# Altium schematic sheet BotStop_LCD_WIFI_CON.SchDoc
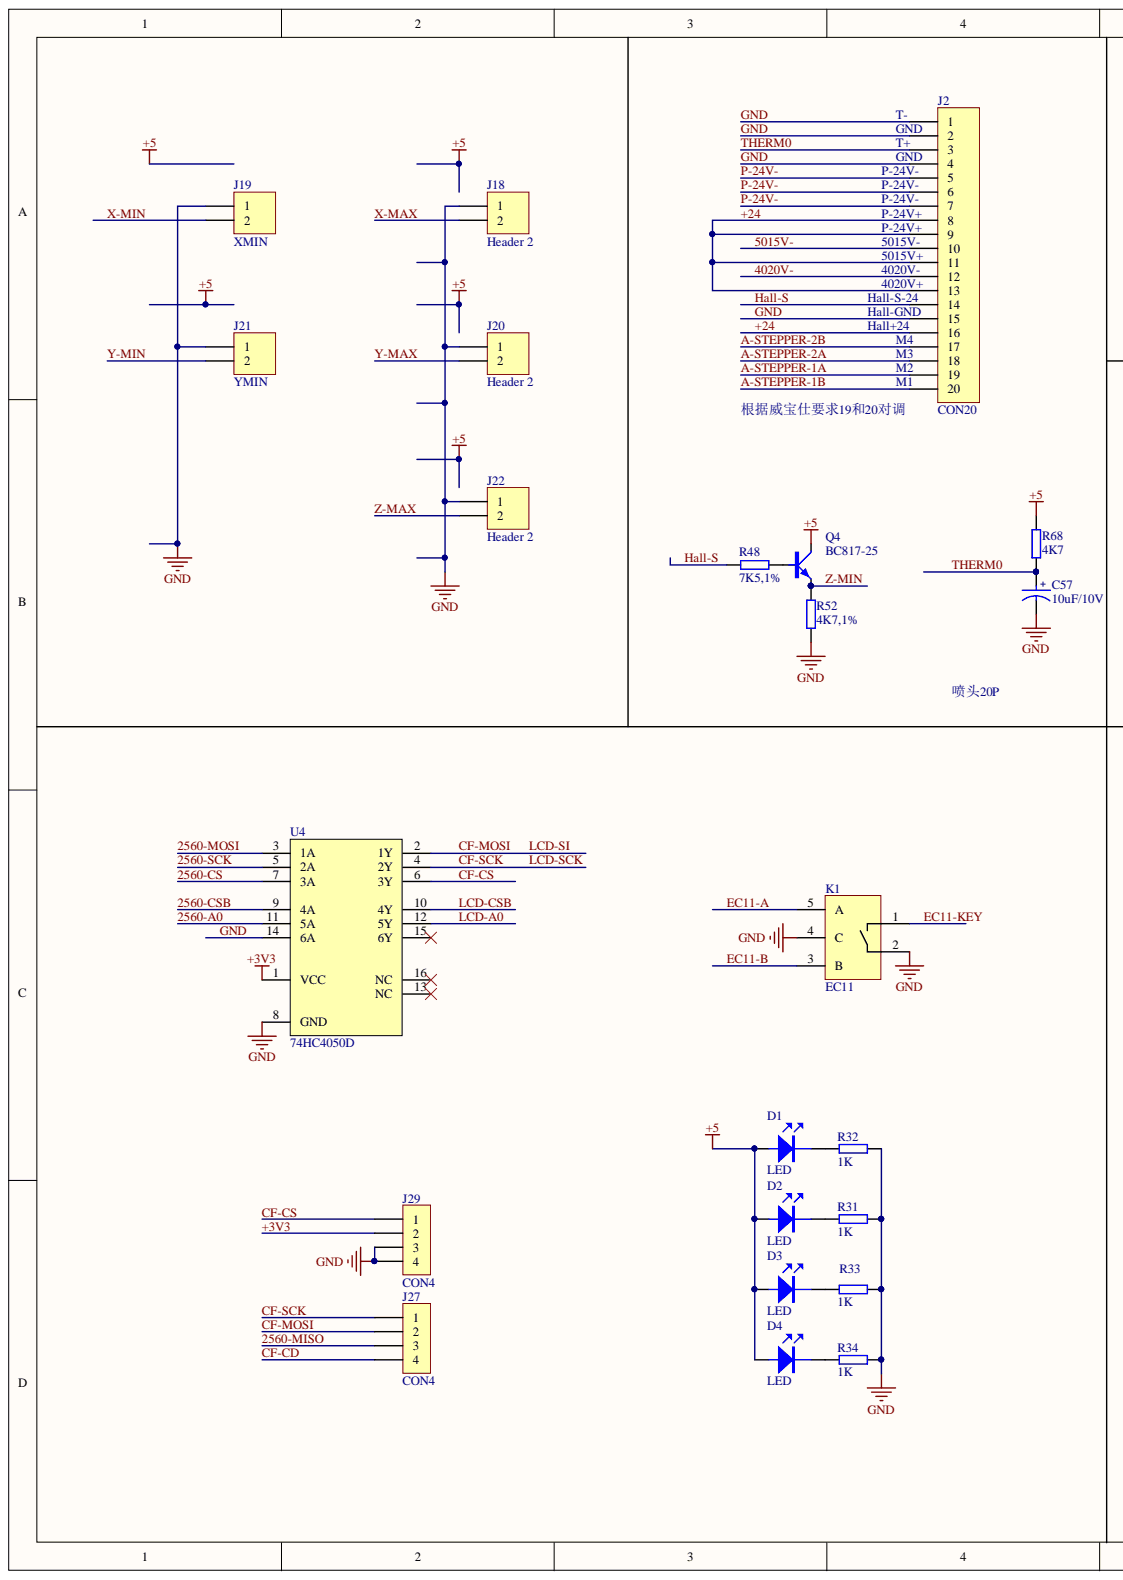
[diagram p0: BotStop_LCD_WIFI_CON.SchDoc, left]
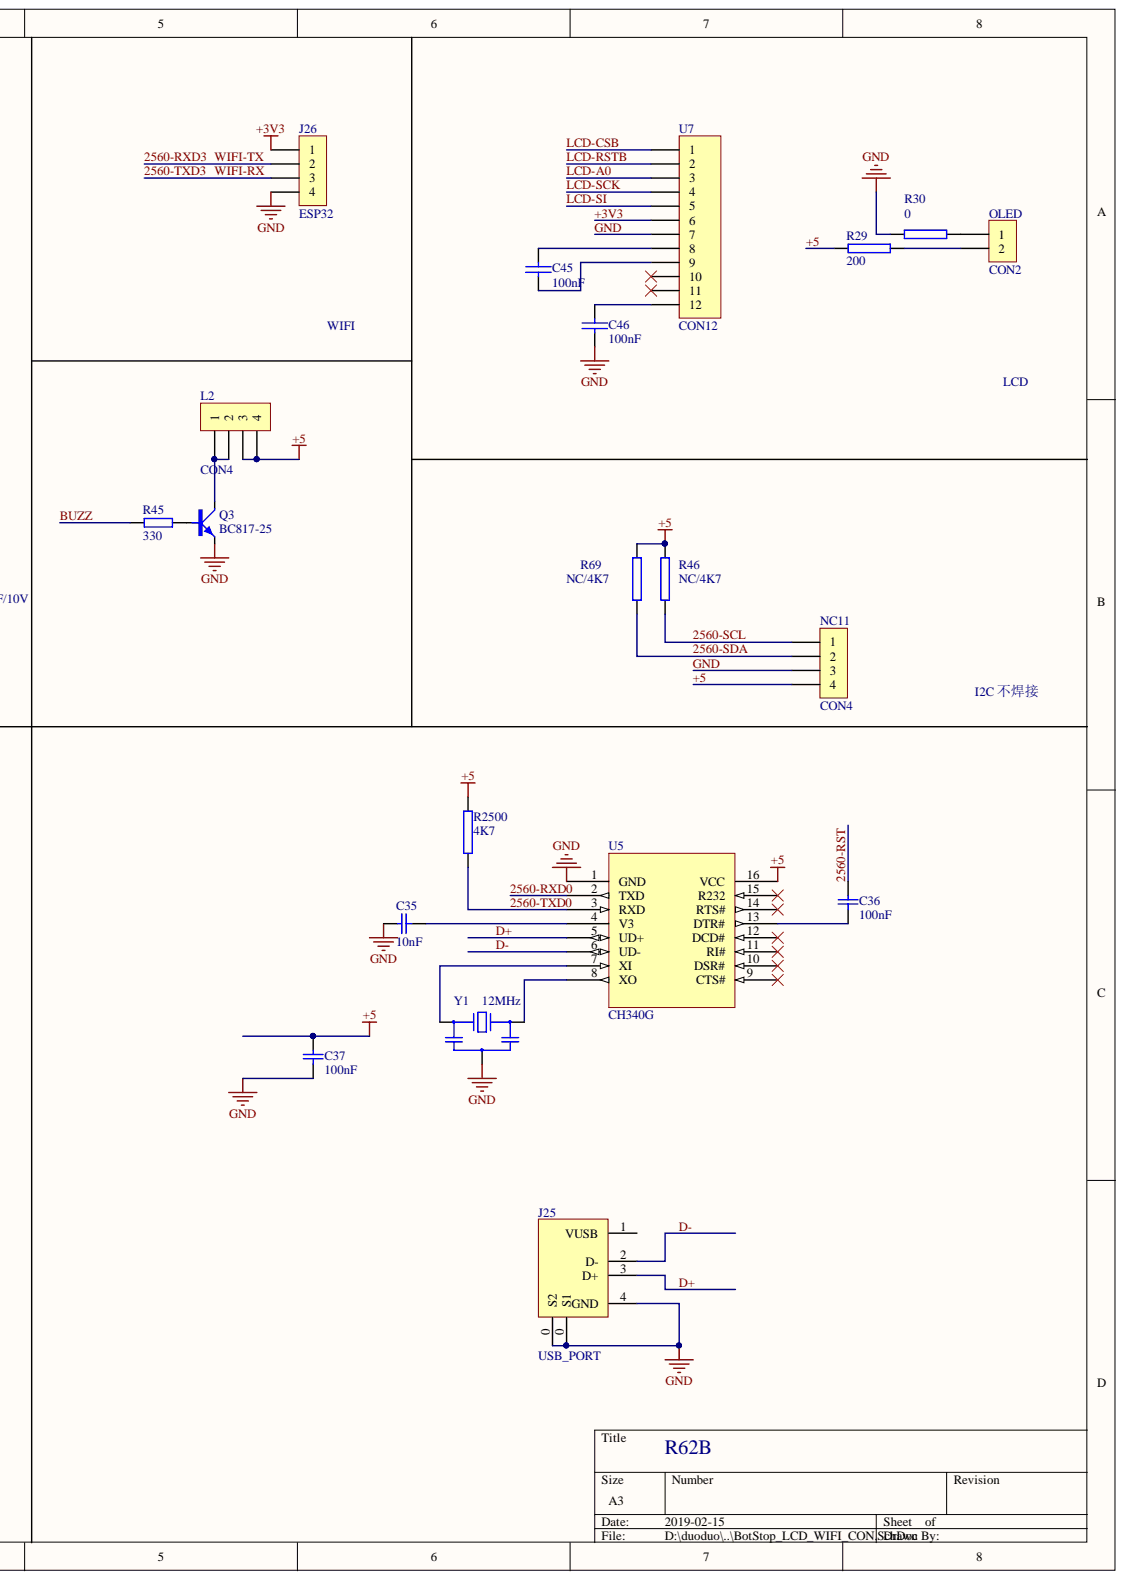
[diagram p1: BotStop_LCD_WIFI_CON.SchDoc, right]

## Parts
C35  10nF  [0603]
C36  100nF  [0603]
C37  100nF  [0603]
C45  100nF  [0603]
C46  100nF  [0603]
C57  10uF/10V  [1206]
D1  LED  [0805D]
D2  LED  [0805D]
D3  LED  [0805D]
D4  LED  [0805D]
J2  CON20  [IDC-20-100H-N]
J18  Header 2  [XH2.54-2]
J19  XMIN  [XH2.54-2]
J20  Header 2  [XH2.54-2]
J21  YMIN  [XH2.54-2]
J22  Header 2  [XH2.54-2]
J25  USB_PORT  [USB-B]
J26  ESP32  [XH2.54-4-A]
J27  CON4  [23]
J29  CON4  [23]
K1  EC11  [EC11-SMT]
L2  CON4  [BUZZ-SMT]
NC11  CON4  [SIP-4]
OLED  CON2  [OLED]
Q3  BC817-25  [SOT-23-3L]
Q4  BC817-25  [SOT-23-3L]
R29  200  [0603]
R30  0  [0603]
R31  1K  [0603]
R32  1K  [0603]
R33  1K  [0603]
R34  1K  [0603]
R45  330  [0603]
R46  NC/4K7  [0603]
R48  7K5,1%  [0603]
R52  4K7,1%  [0603]
R68  4K7  [0603]
R69  NC/4K7  [0603]
R2500  4K7  [0603]
U4  74HC4050D  [LC-SOIC-16_150mil]
U5  CH340G  [LC-SOIC-16_150mil]
U7  CON12  [FPC12-0.5]
Y1  12MHz  [CSTCE-G]

## Nets
2560-A0: U4.11(5A)
2560-CS: U4.7(3A)
2560-CSB: U4.9(4A)
2560-MISO: J27.3
2560-MOSI: U4.3(1A)
2560-RST: C36.1
2560-RXD0: U5.2(TXD)
2560-RXD3: J26.2
2560-SCK: U4.5(2A)
2560-SCL: NC11.1 R46.1
2560-SDA: NC11.2 R69.1
2560-TXD0: R2500.2 U5.3(RXD)
2560-TXD3: J26.3
4020V-: J2.12
5015V-: J2.10
+3V3: J26.1 J29.2 U4.1(VCC) U7.6
+5: C37.1 D1.1 D2.1 D3.1 D4.1 L2.3 L2.4 NC11.4 Q4.3 R29.2 R46.2 R68.2 R69.2 R2500.1 U5.16(VCC)
+24: J2.8 J2.9 J2.11 J2.13 J2.16
A-STEPPER-1A: J2.19
A-STEPPER-1B: J2.20
A-STEPPER-2A: J2.18
A-STEPPER-2B: J2.17
BUZZ: R45.1
CF-CD: J27.4
CF-CS: J29.1 U4.6(3Y)
CF-MOSI: J27.2 U4.2(1Y) U7.5
CF-SCK: J27.1 U4.4(2Y) U7.4
D+: J25.3(D+) U5.5(UD+)
D-: J25.2(D-) U5.6(UD-)
EC11-A: K1.5(A)
EC11-B: K1.3(B)
EC11-KEY: K1.1
GND: C35.1 C37.2 C46.1 C57.2 J2.1 J2.2 J2.4 J2.15 J18.1 J19.1 J20.1 J21.1 J22.1 J25.0(S2) J25.4(GND) J26.4 J29.3 J29.4 K1.2 K1.4(C) NC11.3 Q3.2 R30.1 R31.2 R32.2 R33.2 R34.2 R52.1 U4.8(GND) U4.14(6A) U5.1(GND) U7.7 Y1.2
Hall-S: J2.14 R48.2
LCD-A0: U4.12(5Y) U7.3
LCD-CSB: U4.10(4Y) U7.1
LCD-RSTB: U7.2
P-24V-: J2.5 J2.6 J2.7
THERM0: C57.1 J2.3 R68.1
X-MAX: J18.2
X-MIN: J19.2
Y-MAX: J20.2
Y-MIN: J21.2
Z-MAX: J22.2
Z-MIN: Q4.2 R52.2
NetC35_2: C35.2 U5.4(V3)
NetC36_2: C36.2 U5.13(DTR#)
NetC45_1: C45.1 U7.9
NetC45_2: C45.2 U7.8
NetC46_2: C46.2 U7.12
NetD1_2: D1.2 R32.1
NetD2_2: D2.2 R31.1
NetD3_2: D3.2 R33.1
NetD4_2: D4.2 R34.1
NetL2_1: L2.1 L2.2 Q3.3
NetOLED_1: OLED.1 R30.2
NetOLED_2: OLED.2 R29.1
NetQ3_1: Q3.1 R45.2
NetQ4_1: Q4.1 R48.1
NetU5_7: U5.7(XI) Y1.1
NetU5_8: U5.8(XO) Y1.3

Not connected: J25 1(VUSB); U4 13(NC) 15(6Y) 16(NC); U5 9(CTS#) 10(DSR#) 11(RI#) 12(DCD#) 14(RTS#) 15(R232); U7 10 11

## Library data of the parts
C35-C37 C45 C46 = CAP: Capacitor; Component Kind: Standard
  C35-C37: Library Name: DMSch.SCHLIB
  C45 C46: Library Name: Miscellaneous Devices.SCHLIB
C57 = CAPACITOR POL: Component Kind: Standard; Description: Capacitor Pol; Library Name: DMSch.SCHLIB
J2 = CON20: Connector; Component Kind: Standard; Library Name: Miscellaneous Devices.SCHLIB
J18-J22 = Header 2: Header, 2-Pin; Component Kind: Standard; LatestRevisionDate: 17-Jul-2002; LatestRevisionNote: Re-released for DXP Platform.; Library Name: Miscellaneous Connectors.IntLib; Signal Integrity: Connector; Publisher: Altium Limited
J25 = USB_PORT: USB-PORT; Component Kind: Standard; Library Name: DMSch.SCHLIB
J26 J27 J29 L2 NC11 = CON4: Connector; Component Kind: Standard; Library Name: Miscellaneous Devices.SCHLIB
OLED = CON2: Connector; Component Kind: Standard; Library Name: Miscellaneous Devices.SCHLIB
Q3 Q4 = NPN_1: PNP Transistor; Component Kind: Standard; Library Name: DMSch.SCHLIB
R29 R30 R46 R69 R2500 = RES2: Component Kind: Standard
  R29 R30 R46 R69: Library Name: Miscellaneous Devices.SCHLIB
  R2500: Library Name: DMSch.SCHLIB
R31-R34 R45 R48 R52 R68 = RES5: Component Kind: Standard; Library Name: DMSch.SCHLIB
U5 = CH340G: USB to TTL Serial / UART; Component Kind: Standard; Library Name: CH340SCH.LIB
U7 = CON12: Connector; Component Kind: Standard; Library Name: Miscellaneous Devices.SCHLIB
Y1 = CRYSTAL-3: Crystal; Component Kind: Standard; Library Name: DMSch.SCHLIB
D1-D4 = LED: Component Kind: Standard; Description: LED; Library Name: DMSch.SCHLIB
K1 = EC11: Component Kind: Standard; Library Name: DMSch.SCHLIB
U4 = 74HC4050D: Component Kind: Standard; Library Name: DMSch.SCHLIB
